# Altium schematic sheet Synaps_Power_V1I2.SchDoc
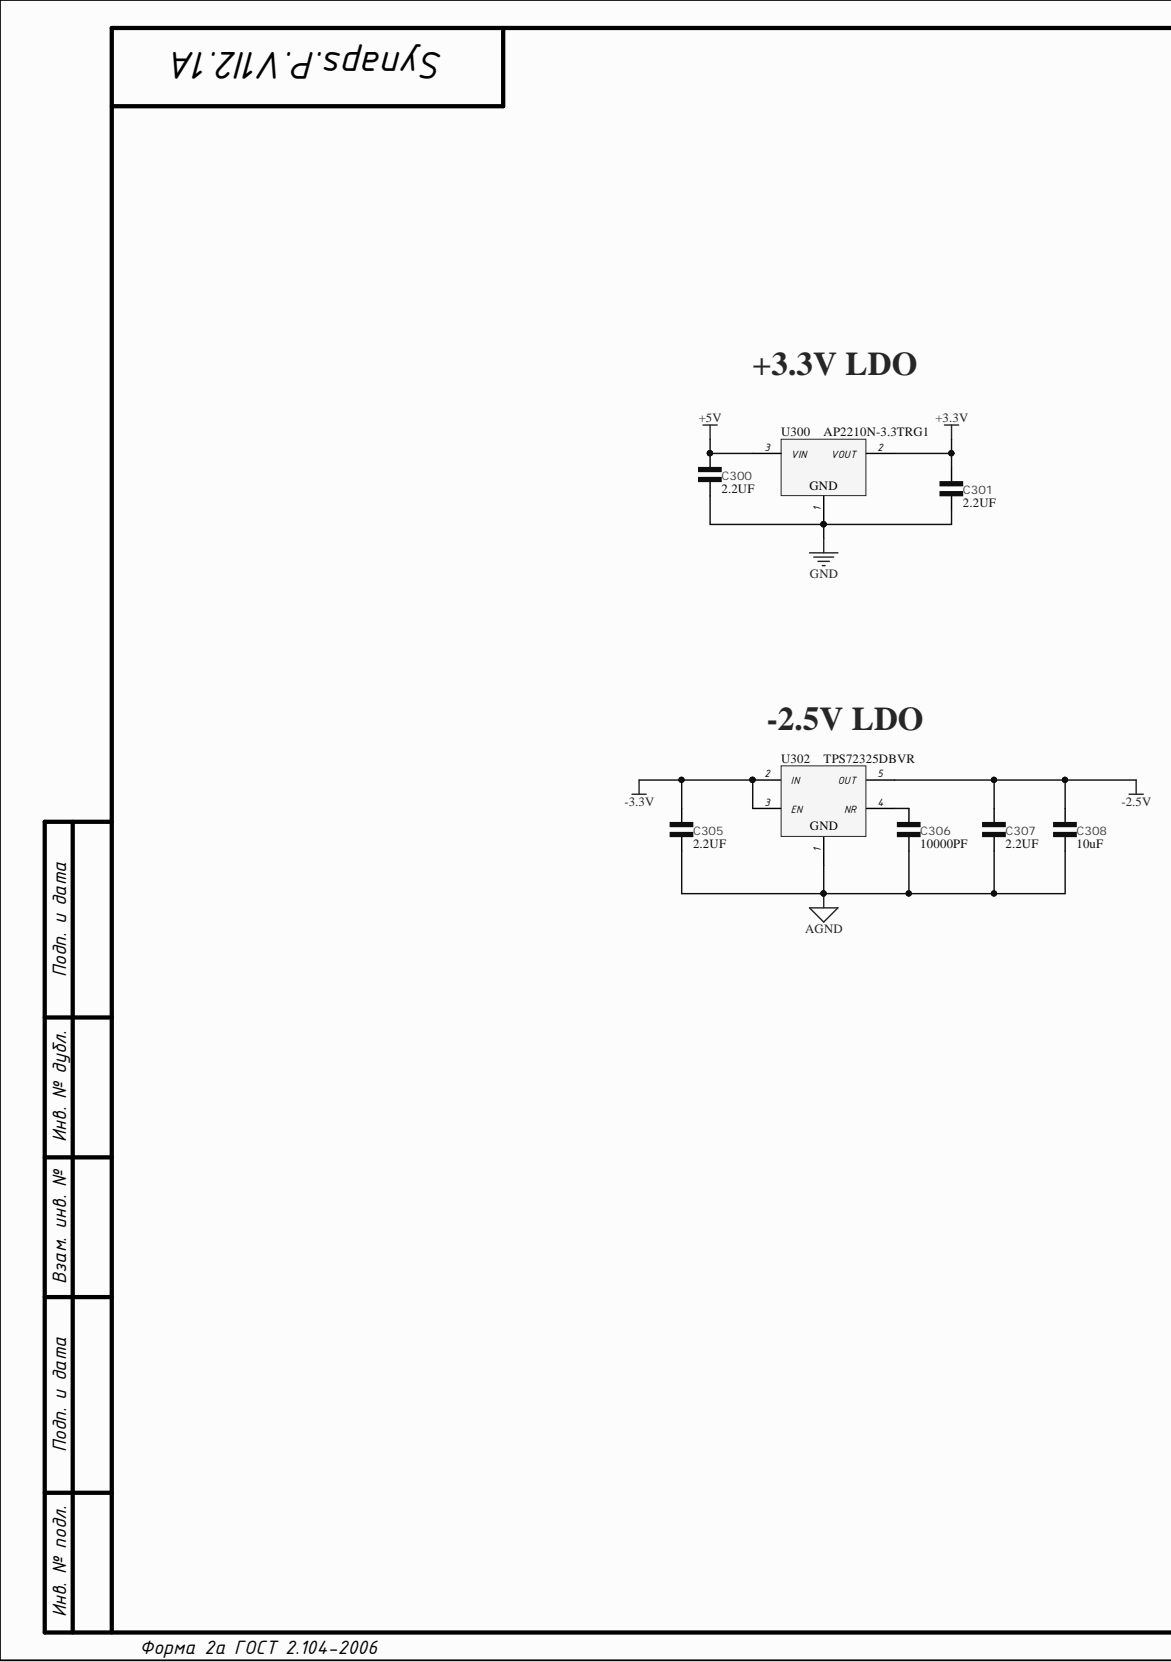
[diagram p0: Synaps_Power_V1I2.SchDoc, left]
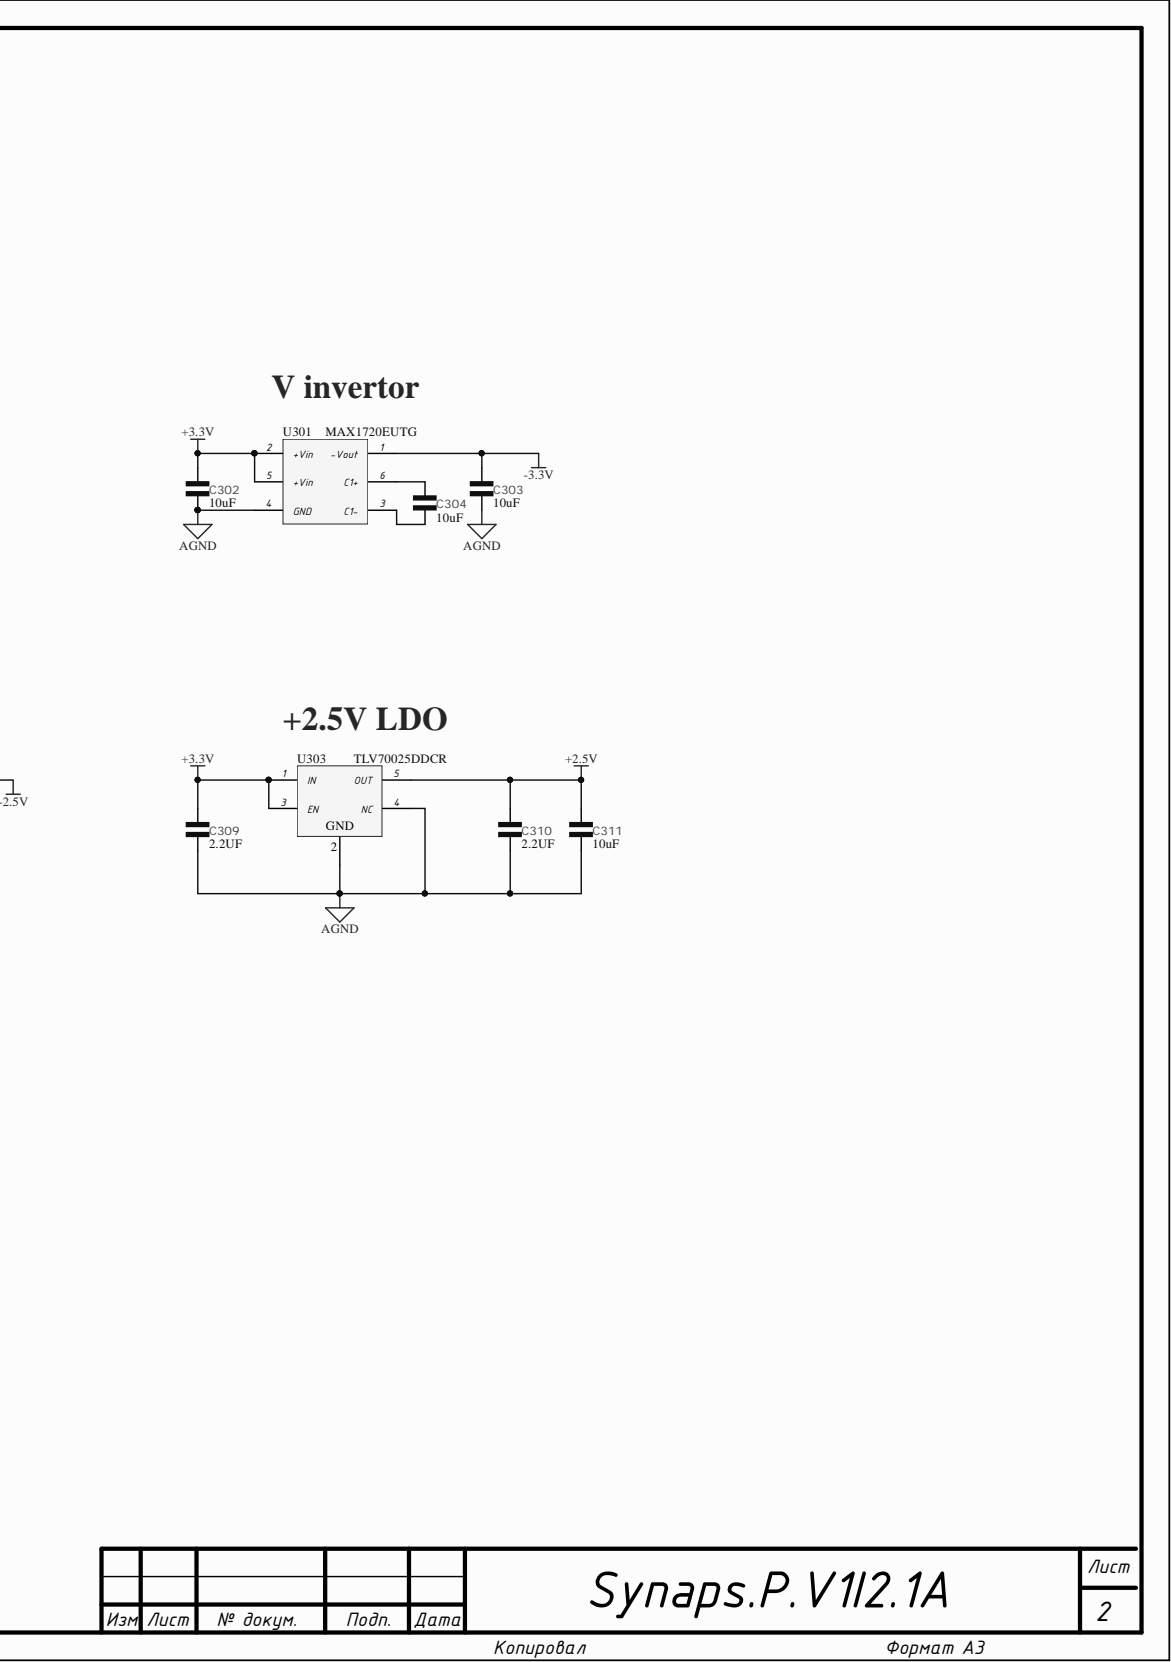
[diagram p1: Synaps_Power_V1I2.SchDoc, right]

## Parts
C300  value 2.2UF  [C0603]  (hidden comment: GRM188R61E225MA12D)
C301  value 2.2UF  [C0603]  (hidden comment: GRM188R61E225MA12D)
C302  value 10uF  Capacitance: 10uF  [C0603]  (hidden comment: GRM188C80G106ME47D)
C303  value 10uF  Capacitance: 10uF  [C0603]  (hidden comment: GRM188C80G106ME47D)
C304  value 10uF  Capacitance: 10uF  [C0603]  (hidden comment: GRM188C80G106ME47D)
C305  value 2.2UF  [C0603]  (hidden comment: GRM188R61E225MA12D)
C306  value 10000PF  [C0603]  (hidden comment: CC0603KRX7R9BB103)
C307  value 2.2UF  [C0603]  (hidden comment: GRM188R61E225MA12D)
C308  value 10uF  Capacitance: 10uF  [C0603]  (hidden comment: GRM188C80G106ME47D)
C309  value 2.2UF  [C0603]  (hidden comment: GRM188R61E225MA12D)
C310  value 2.2UF  [C0603]  (hidden comment: GRM188R61E225MA12D)
C311  value 10uF  Capacitance: 10uF  [C0603]  (hidden comment: GRM188C80G106ME47D)
U300  AP2210N-3.3TRG1  [SOT23_N]
U301  MAX1720EUTG  [6ST1_N]
U302  TPS72325DBVR  [SOT23-5]
U303  TLV70025DDCR  [SOT23-5]

## Nets
+2.5V: C310.2 C311.2 U303.5(OUT)
+3.3V: C301.2 C302.2 C309.2 U300.2 U301.2(+Vin) U301.5(+Vin) U303.1(IN) U303.3(EN)
+5V: C300.2 U300.3
-2.5V: C307.2 C308.2 U302.5(OUT)
-3.3V: C303.2 C305.2 U301.1(-Vout) U302.2(IN) U302.3(EN)
AGND: C302.1 C303.1 C305.1 C306.1 C307.1 C308.1 C309.1 C310.1 C311.1 U301.4(GND) U302.1 U303.2 U303.4(NC)
GND: C300.1 C301.1 U300.1
NetC304_1: C304.1 U301.3(C1-)
NetC304_2: C304.2 U301.6(C1+)
NetC306_2: C306.2 U302.4(NR)

## Library data of the parts
C300 C301 C305 C307 C309 C310 = GRM188R61E225MA12D: CAP CER 2.2UF 25V X5R 0603; Manufacturer: MURATA ELECTRONICS; Capacitance: 2.2µF; Database Table Name: Capacitors_chip; Tolerance: 20%; Applications: General Purpose; Category: Capacitors; Component Kind: Standard; ComponentLink1Description: Datasheet; ComponentLink1URL: http://www.murata.com/~/media/webrenewal/support/library/catalog/products/capacitor/mlcc/c02e.ashx; Component Type: Standard; Cost: 0,003927; Designator: C?; Features: -; Height - Seated (Max): -; Lead Spacing: -; Lead Style: -; Library Name: AIComponents.DbLib; Mounting Type: Surface Mount, MLCC; Operating Temperature: -55°C ~ 85°C; Package / Case: 0603 (1608 Metric); Part Number: GRM188R61E225MA12D; Pin Count: 2; Ratings: -; Size / Dimension: 0.063" L x 0.031" W (1.60mm x 0.80mm); Stock: 3235; Temperature Coefficie: X5R; Temperature Coefficient: X5R; Thickness (Max): 0.035" (0.90mm); Voltage: 25V; Voltage - Rated: 25V
C302-C304 C308 C311 = GRM188C80G106ME47D: CAP CER 10UF 4V X6S 0603; Manufacturer: Murata; Database Table Name: Capacitors_chip; Voltage: 6.3V; Component Kind: Standard; ComponentLink1Description: Datasheet; ComponentLink1URL: http://search.murata.co.jp/Ceramy/image/img/A01X/G101/ENG/GRM188C80G106ME47-01.pdf; Component Type: Standard; Cost: 0; Designator: C?; Library Name: AIComponents.DbLib; Part Number: GRM188C80G106ME47D; Stock: 0
C306 = CC0603KRX7R9BB103: CAP CER 10000PF 50V X7R 0603; Manufacturer: YAGEO; Database Table Name: Capacitors_chip; Tolerance: ±10%; Applications: General Purpose; Capacitance: 10000pF; Category: Capacitors; Component Kind: Standard; ComponentLink1Description: Datasheet; ComponentLink1URL: http://www.yageo.com/documents/recent/UPY-GPHC_X7R_6.3V-to-50V_17.pdf; Component Type: Standard; Cost: 0,00205871636956301; Designator: C?; Failure Rate: -; Features: -; Height - Seated (Max): -; Lead Spacing: -; Lead Style: -; Library Name: AIComponents.DbLib; Mounting Type: Surface Mount, MLCC; Operating Temperature: -55°C ~ 125°C; Package / Case: 0603 (1608 Metric); Part Number: CC0603KRX7R9BB103; Pin Count: 2; Ratings: -; Size / Dimension: 0.063" L x 0.031" W (1.60mm x 0.80mm); Stock: 4096; Temperature Coefficie: X7R; Temperature Coefficient: X7R; Thickness (Max): 0.035" (0.90mm); Voltage: 50V; Voltage - Rated: 50V
U300 = AP2210N-3.3TRG1: IC REG LDO 3.3V 0.3A SOT23; Manufacturer: Diodes Incorporated; Database Table Name: IC_Modules; Category: Integrated Circuits (ICs); Component Kind: Standard; Component Type: Standard; Cost: 0,175137290186529; Designator: U?; Library Name: AIComponents.DbLib; Part Number: AP2210N-3.3TRG1; Pin Count: 3; Stock: 406
U301 = MAX1720EUTG: IC REG SWTCHD CAP INV 50MA 6TOSP; Manufacturer: ON Semiconductor; Database Table Name: IC_Modules; Category: Integrated Circuits (ICs); Component Kind: Standard; ComponentLink1Description: Datasheet; ComponentLink1URL: http://www.onsemi.com/pub/Collateral/MAX1720-D.PDF; Component Type: Standard; Cost: 0; Designator: U?; Library Name: AIComponents.DbLib; Part Number: MAX1720EUTG; Pin Count: 6; Stock: 0
U302 = TPS72325DBVR: IC REG LIN -2.5V 200MA SOT23-5; Manufacturer: TI; Database Table Name: IC_Modules; Category: Integrated Circuits (ICs); Component Kind: Standard; ComponentLink1Description: Datasheet; ComponentLink1URL: http://www.ti.com/lit/ds/symlink/tps723.pdf; Component Type: Standard; Cost: 0; Designator: U?; Library Name: AIComponents.DbLib; Part Number: TPS72325DBVR; Pin Count: 5; Stock: 0
U303 = TLV70025DDCR: IC REG LINEAR 2.5V 200MA SOT23-5; Manufacturer: TI; Database Table Name: IC_Modules; Category: Integrated Circuits (ICs); Component Kind: Standard; ComponentLink1Description: Datasheet; ComponentLink1URL: http://www.ti.com/lit/ds/slvsa00e/slvsa00e.pdf; Component Type: Standard; Cost: 0; Designator: U?; Library Name: AIComponents.DbLib; Part Number: TLV70025DDCR; Stock: 0
